# Altium schematic sheet LED.SchDoc
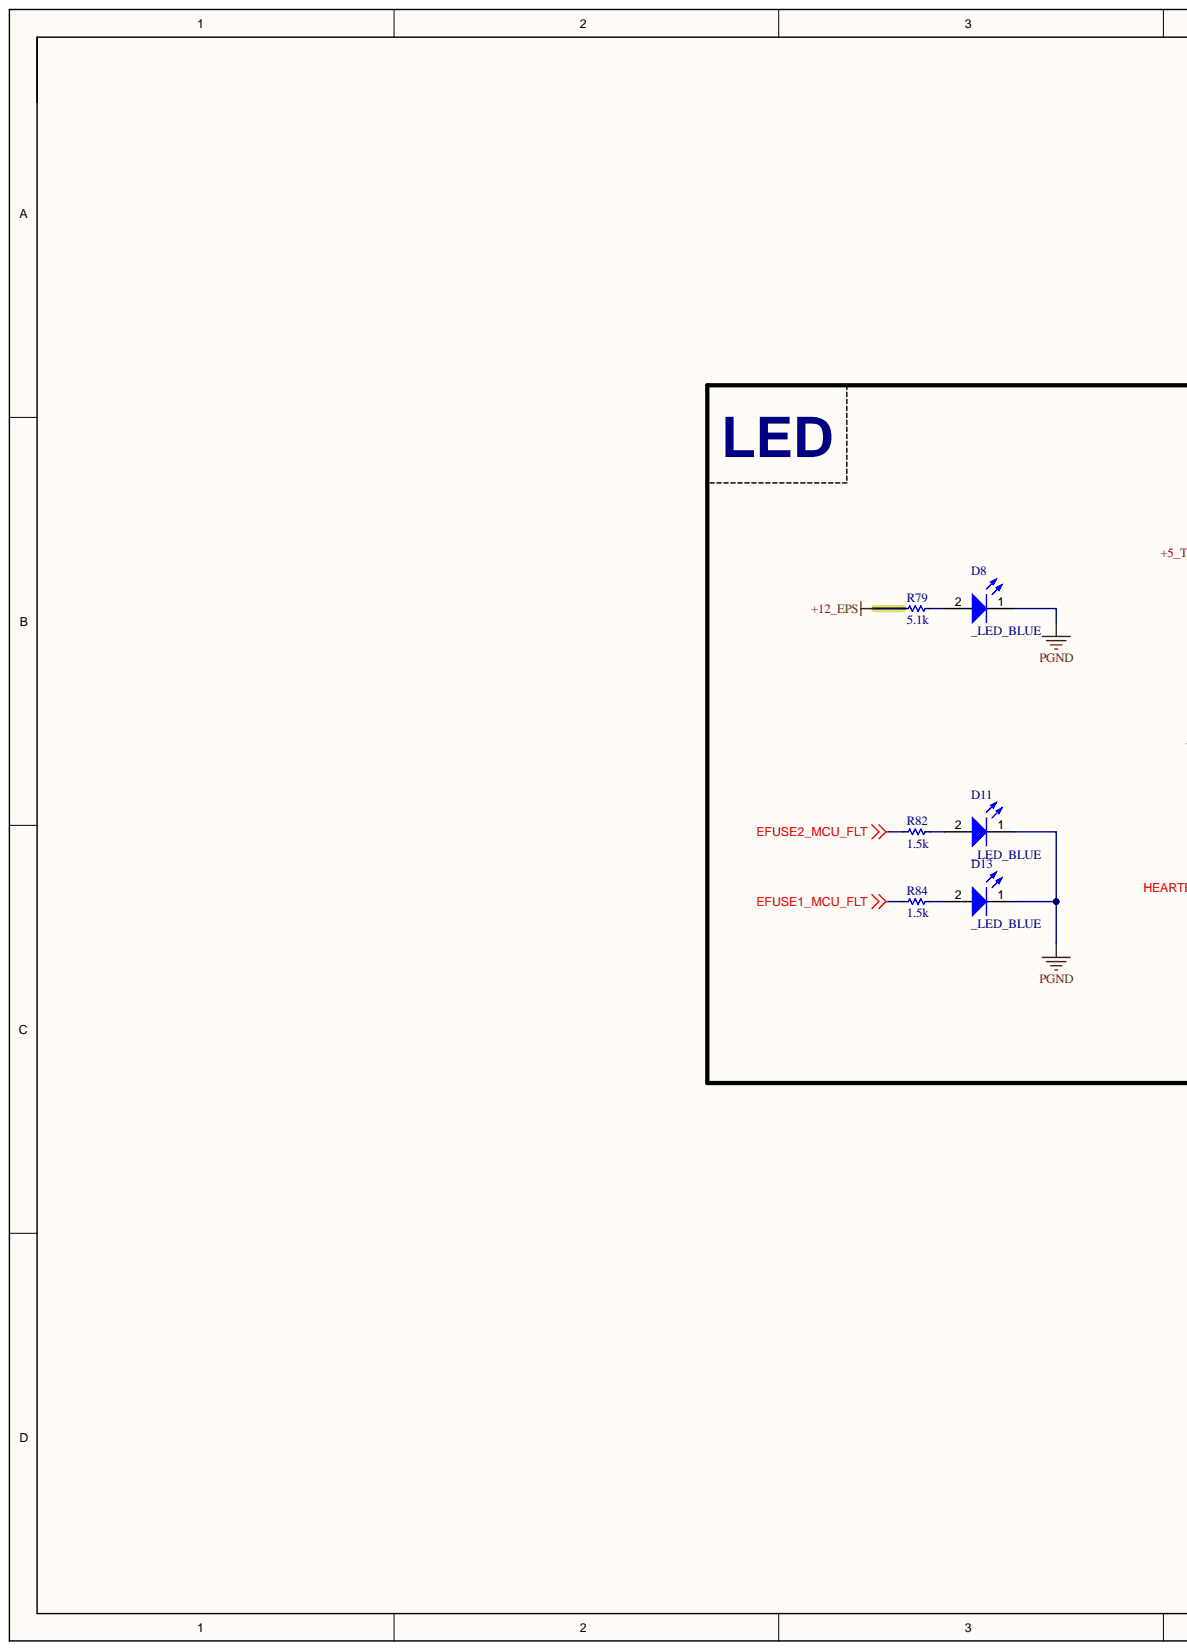
[diagram p0: LED.SchDoc, left]
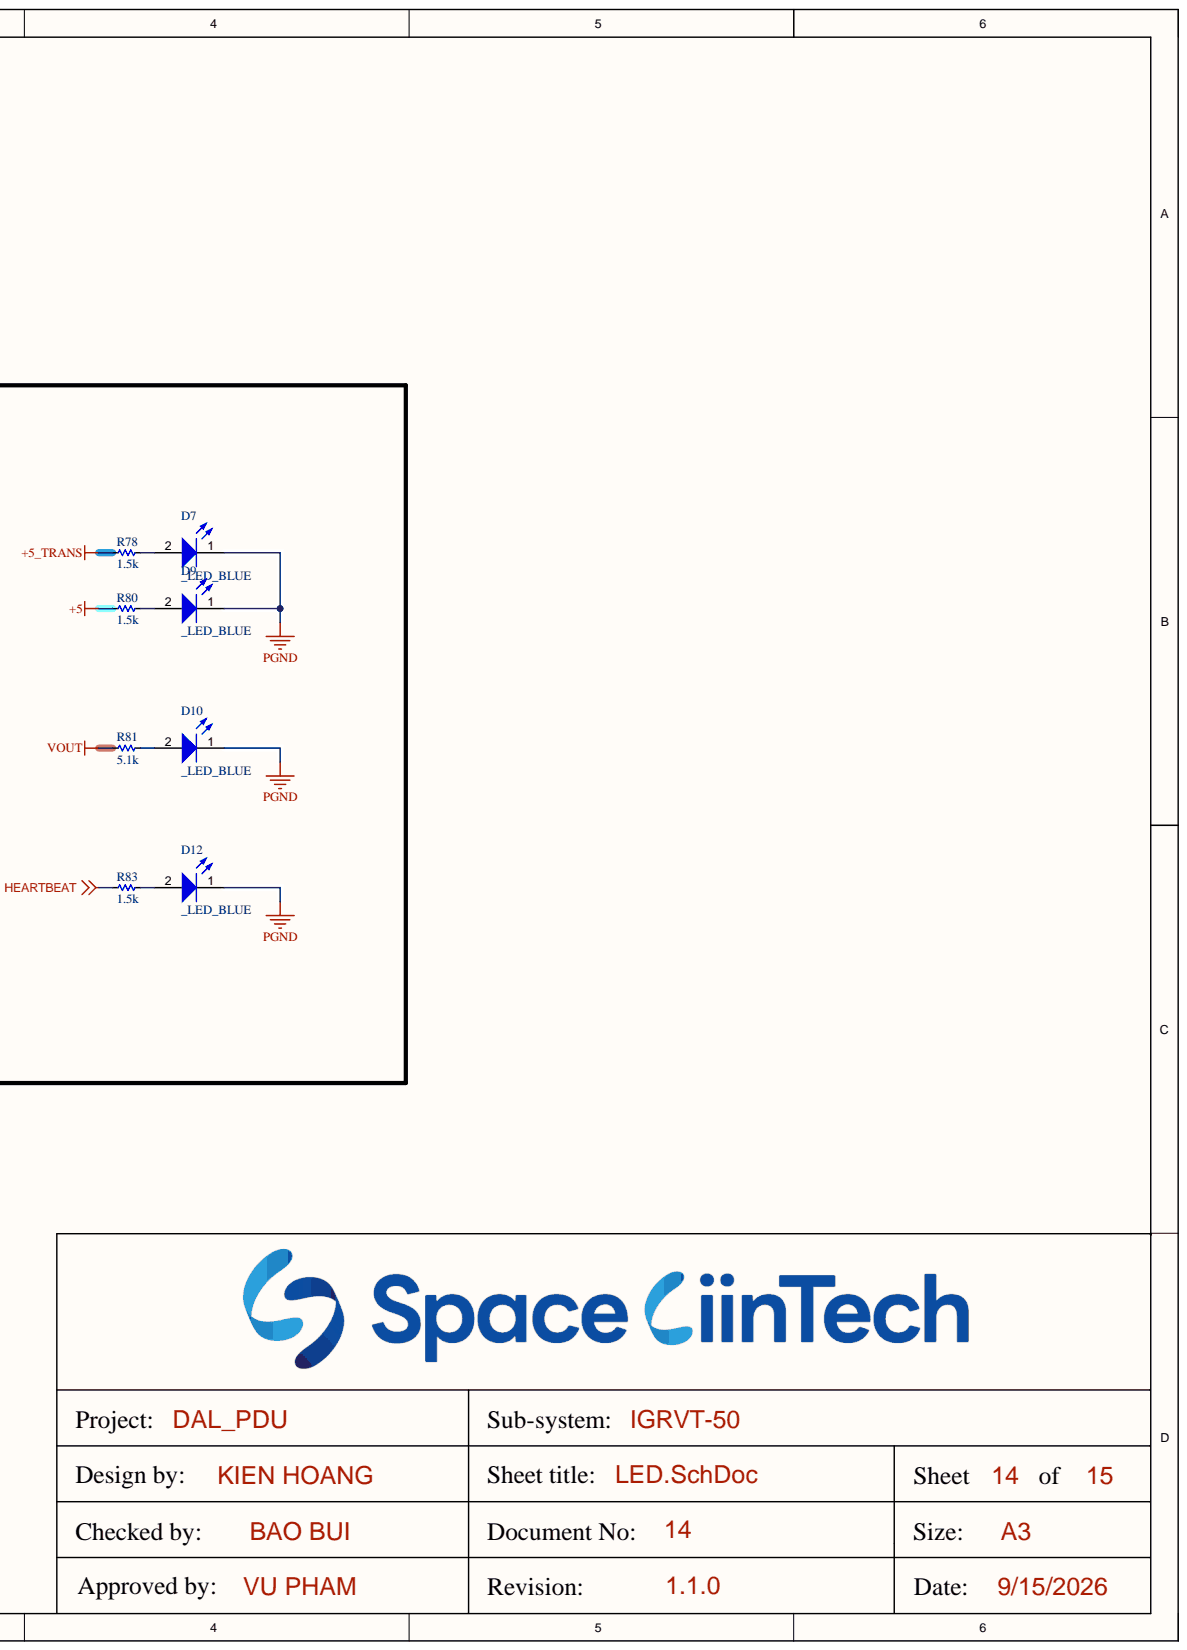
[diagram p1: LED.SchDoc, right]

## Parts
D7  _LED_BLUE  [LED_0603]
D8  _LED_BLUE  [LED_0603]
D9  _LED_BLUE  [LED_0603]
D10  _LED_BLUE  [LED_0603]
D11  _LED_BLUE  [LED_0603]
D12  _LED_BLUE  [LED_0603]
D13  _LED_BLUE  [LED_0603]
R78  1.5k  [RES_C_1608X60]
R79  5.1k  [RES_C_1608X60]
R80  1.5k  [RES_C_1608X60]
R81  5.1k  [RES_C_1608X60]
R82  1.5k  [RES_C_1608X60]
R83  1.5k  [RES_C_1608X60]
R84  1.5k  [RES_C_1608X60]

## Nets
+5: R80.2
+5_TRANS: R78.2
+12_EPS: R79.2
EFUSE1_MCU_FLT: R84.2
EFUSE2_MCU_FLT: R82.2
HEARTBEAT: R83.2
PGND: D7.1 D8.1 D9.1 D10.1 D11.1 D12.1 D13.1
VOUT: R81.2
NetD7_2: D7.2 R78.1
NetD8_2: D8.2 R79.1
NetD9_2: D9.2 R80.1
NetD10_2: D10.2 R81.1
NetD11_2: D11.2 R82.1
NetD12_2: D12.2 R83.1
NetD13_2: D13.2 R84.1

## Library data of the parts
R78-R84 = _RES_B_2_2: RES; Component Kind: Standard; Library Name: LABLIB.SchLib
D7-D13 = _LED_BLUE: Component Kind: Standard; Library Name: LABLIB.SchLib
